# Altium schematic sheet drv.SchDoc
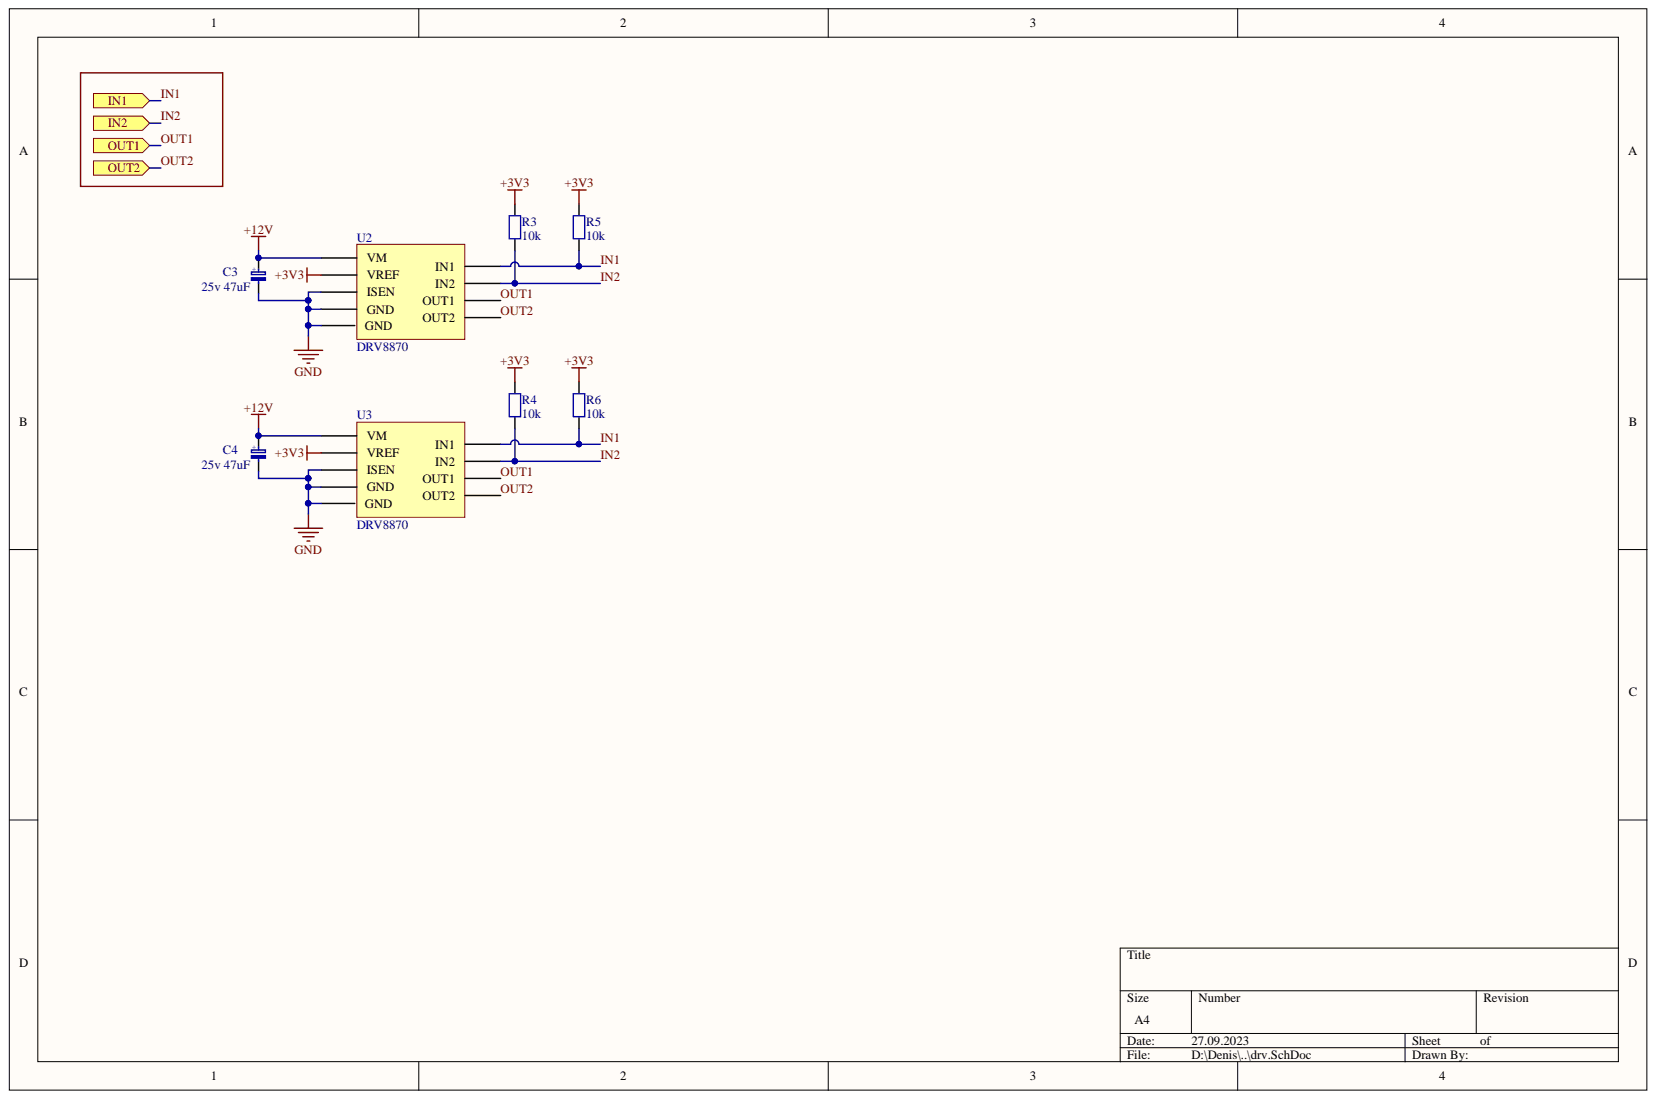
[diagram p0: drv.SchDoc]

## Parts
C3  25v 47uF  [Tantal C]
C4  25v 47uF  [Tantal C]
R3  10k  [res0603]
R4  10k  [res0603]
R5  10k  [res0603]
R6  10k  [res0603]
U2  DRV8870  [8-Pin HSOP]
U3  DRV8870  [8-Pin HSOP]

## Ports
IN1, IN2, OUT1, OUT2

## Nets
+3V3: R3.2 R4.2 R5.2 R6.2 U2.4(VREF) U3.4(VREF)
+12V: C3.2 C4.2 U2.5(VM) U3.5(VM)
GND: C3.1 C4.1 U2.1(GND) U2.7(ISEN) U2.9(GND) U3.1(GND) U3.7(ISEN) U3.9(GND)
IN1: R5.1 R6.1 U2.2(IN1) U3.2(IN1) ; port IN1
IN2: R3.1 R4.1 U2.3(IN2) U3.3(IN2) ; port IN2
OUT1: U2.6(OUT1) U3.6(OUT1) ; port OUT1
OUT2: U2.8(OUT2) U3.8(OUT2) ; port OUT2

## Library data of the parts
C3 C4 = Cap Tantal: Component Kind: Standard; Library Name: GKirik.SchLib
R3-R6 = Resistor SMD: Component Kind: Standard; Library Name: GKirik.SchLib
U2 U3 = DRV8870: Component Kind: Standard; Library Name: GKirik.SchLib
